# Altium schematic sheet DMMSwitchBoard.SchDoc
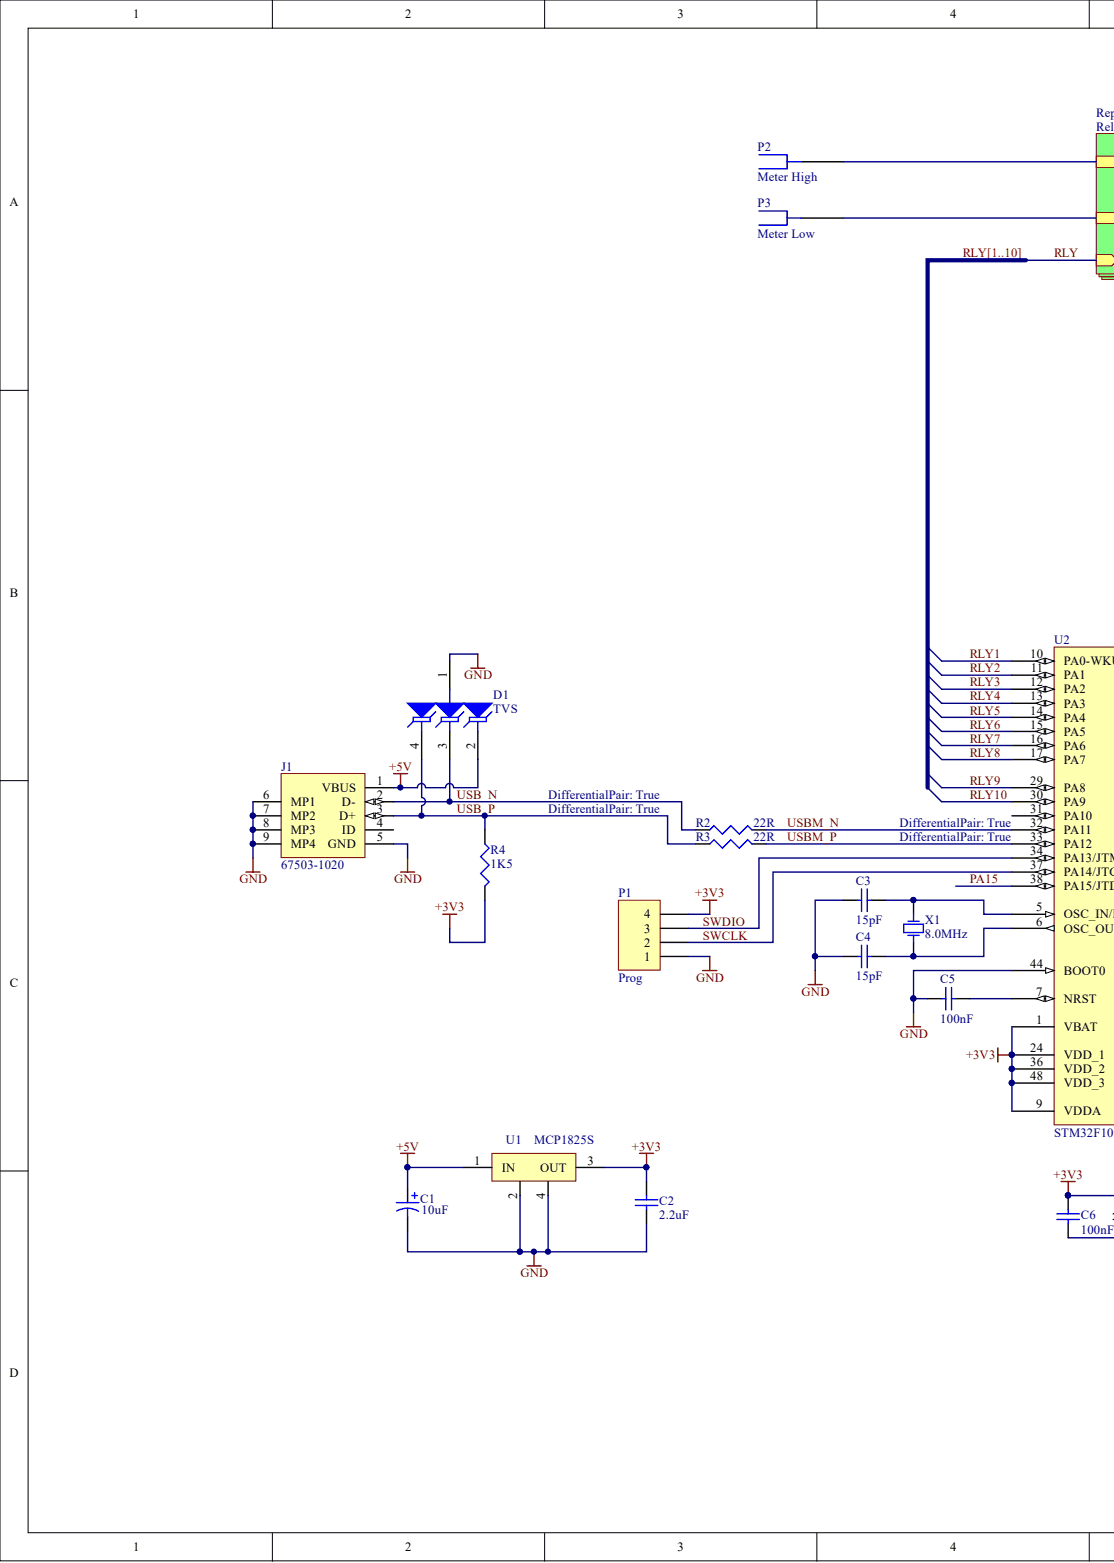
[diagram p0: DMMSwitchBoard.SchDoc, left]
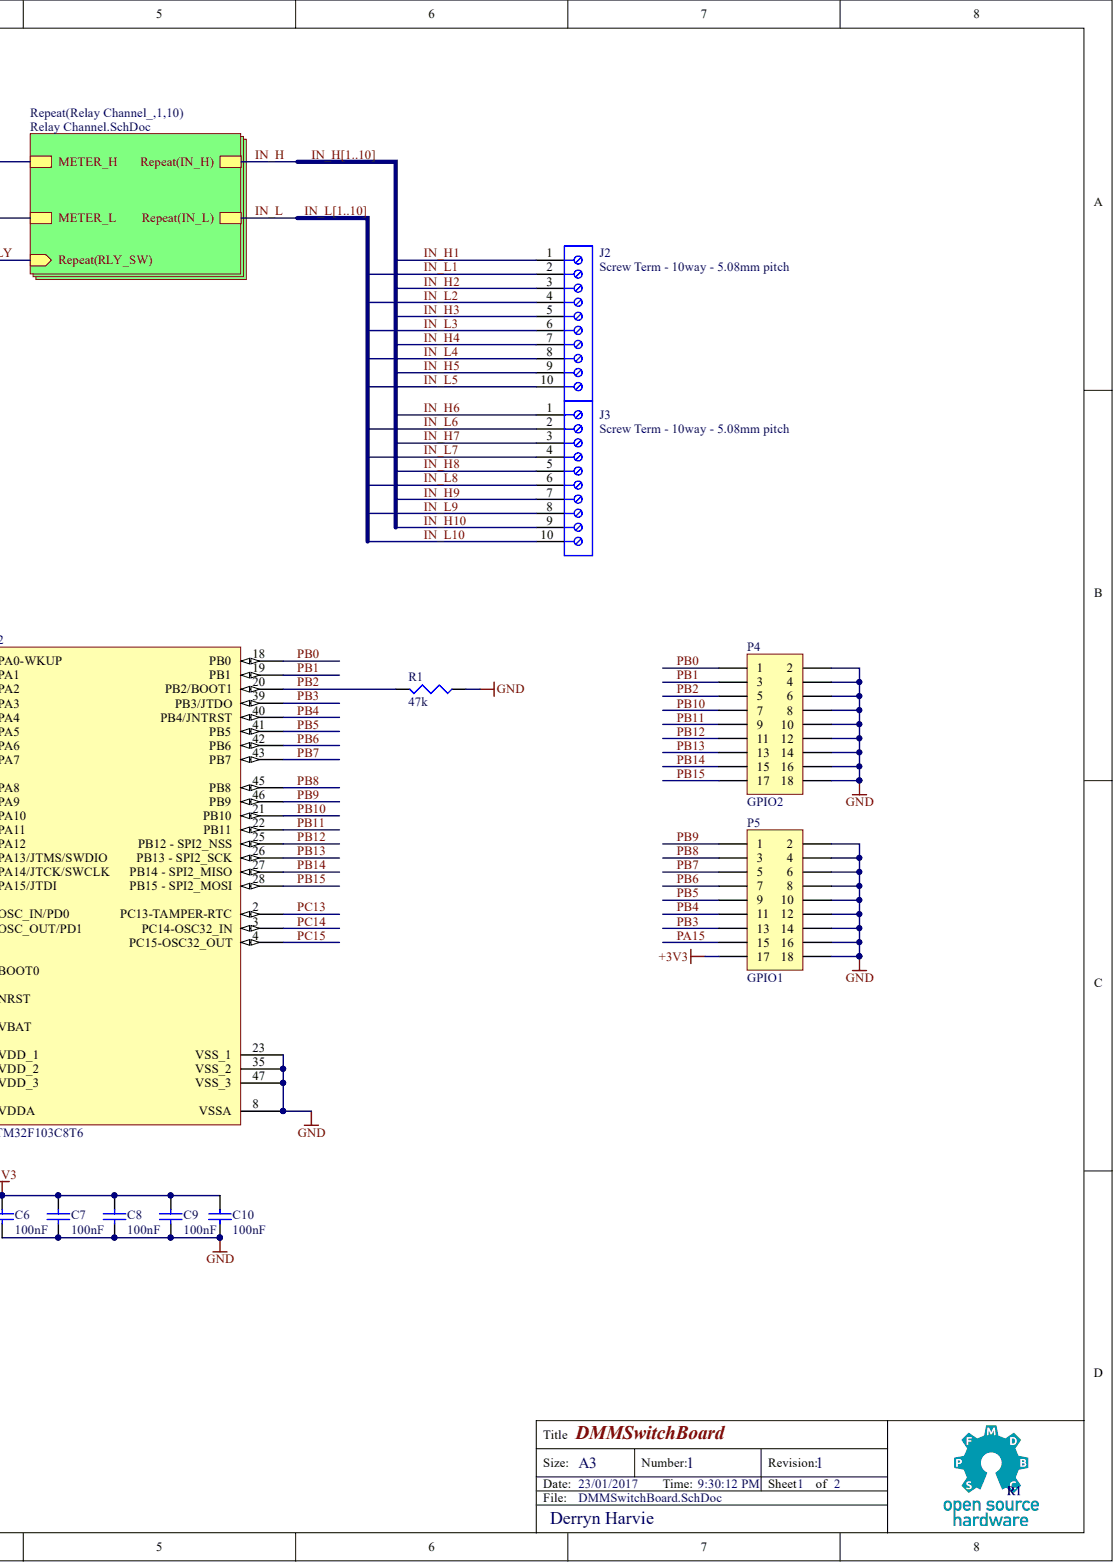
[diagram p1: DMMSwitchBoard.SchDoc, right]

## Parts
C1  value 10uF  [0805]  (hidden comment: Cap Pol2)
C2  value 2.2uF  [0603]  (hidden comment: C0603)
C3  value 15pF  [0603]  (hidden comment: C0603)
C4  value 15pF  [0603]  (hidden comment: C0603)
C5  value 100nF  [0603]  (hidden comment: C0603)
C6  value 100nF  [0603]  (hidden comment: C0603)
C7  value 100nF  [0603]  (hidden comment: C0603)
C8  value 100nF  [0603]  (hidden comment: C0603)
C9  value 100nF  [0603]  (hidden comment: C0603)
C10  value 100nF  [0603]  (hidden comment: C0603)
D1  TVS  [SOT143-4]
J1  67503-1020  [MOLX-67503-1020_V]
J2  Screw Term - 10way - 5.08mm pitch  [ST-30W-5.08P]
J3  Screw Term - 10way - 5.08mm pitch  [ST-30W-5.08P]
P1  Prog  [HDR1X4]
P2  Meter High  [Banana_4mm_RA]
P3  Meter Low  [Banana_4mm_RA]
P4  GPIO2  [HDR2X9]
P5  GPIO1  [HDR2X9]
R1  value 47k  [0603]  (hidden comment: Resistor)
R2  value 22R  [0603]  (hidden comment: Resistor)
R3  value 22R  [0603]  (hidden comment: Resistor)
R4  value 1K5  [0603]  (hidden comment: Resistor)
U1  MCP1825S  [SOT223]
U2  STM32F103C8T6  [LQFP48_L]
X1  8.0MHz  [8MHz Crystal]

## Nets
+3V3: C2.1 C6.1 C7.1 C8.1 C9.1 C10.1 P1.4 P5.17 R4.2 U1.3(OUT) U2.1(VBAT) U2.9(VDDA) U2.24(VDD_1) U2.36(VDD_2) U2.48(VDD_3)
+5V: C1.1 D1.2 J1.1(VBUS) U1.1(IN)
GND: C1.2 C2.2 C3.1 C4.1 C5.1 C6.2 C7.2 C8.2 C9.2 C10.2 D1.1 J1.5(GND) J1.6(MP1) J1.7(MP2) J1.8(MP3) J1.9(MP4) P1.1 P4.2 P4.4 P4.6 P4.8 P4.10 P4.12 P4.14 P4.16 P4.18 P5.2 P5.4 P5.6 P5.8 P5.10 P5.12 P5.14 P5.16 P5.18 R1.1 U1.2 U1.4 U2.8(VSSA) U2.23(VSS_1) U2.35(VSS_2) U2.44(BOOT0) U2.47(VSS_3)
IN_H1: J2.1  [also labelled IN_H]
IN_H2: J2.3  [also labelled IN_H]
IN_H3: J2.5  [also labelled IN_H]
IN_H4: J2.7  [also labelled IN_H]
IN_H5: J2.9  [also labelled IN_H]
IN_H6: J3.1  [also labelled IN_H]
IN_H7: J3.3  [also labelled IN_H]
IN_H8: J3.5  [also labelled IN_H]
IN_H9: J3.7  [also labelled IN_H]
IN_H10: J3.9  [also labelled IN_H]
IN_L1: J2.2  [also labelled IN_L]
IN_L2: J2.4  [also labelled IN_L]
IN_L3: J2.6  [also labelled IN_L]
IN_L4: J2.8  [also labelled IN_L]
IN_L5: J2.10  [also labelled IN_L]
IN_L6: J3.2  [also labelled IN_L]
IN_L7: J3.4  [also labelled IN_L]
IN_L8: J3.6  [also labelled IN_L]
IN_L9: J3.8  [also labelled IN_L]
IN_L10: J3.10  [also labelled IN_L]
PA15: P5.15 U2.38(PA15/JTDI)
PB0: P4.1 U2.18(PB0)
PB1: P4.3 U2.19(PB1)
PB2: P4.5 R1.2 U2.20(PB2/BOOT1)
PB3: P5.13 U2.39(PB3/JTDO)
PB4: P5.11 U2.40(PB4/JNTRST)
PB5: P5.9 U2.41(PB5)
PB6: P5.7 U2.42(PB6)
PB7: P5.5 U2.43(PB7)
PB8: P5.3 U2.45(PB8)
PB9: P5.1 U2.46(PB9)
PB10: P4.7 U2.21(PB10)
PB11: P4.9 U2.22(PB11)
PB12: P4.11 U2.25(PB12_-_SPI2_NSS)
PB13: P4.13 U2.26(PB13_-_SPI2_SCK)
PB14: P4.15 U2.27(PB14_-_SPI2_MISO)
PB15: P4.17 U2.28(PB15_-_SPI2_MOSI)
PC13: U2.2(PC13-TAMPER-RTC)
PC14: U2.3(PC14-OSC32_IN)
PC15: U2.4(PC15-OSC32_OUT)
RLY1: U2.10(PA0-WKUP)  [also labelled RLY]
RLY2: U2.11(PA1)  [also labelled RLY]
RLY3: U2.12(PA2)  [also labelled RLY]
RLY4: U2.13(PA3)  [also labelled RLY]
RLY5: U2.14(PA4)  [also labelled RLY]
RLY6: U2.15(PA5)  [also labelled RLY]
RLY7: U2.16(PA6)  [also labelled RLY]
RLY8: U2.17(PA7)  [also labelled RLY]
RLY9: U2.29(PA8)  [also labelled RLY]
RLY10: U2.30(PA9)  [also labelled RLY]
SWCLK: P1.2 U2.37(PA14/JTCK/SWCLK)
SWDIO: P1.3 U2.34(PA13/JTMS/SWDIO)
USB_N: D1.3 J1.2(D-) R2.1
USB_P: D1.4 J1.3(D+) R3.1 R4.1
USBM_N: R2.2 U2.32(PA11)
USBM_P: R3.2 U2.33(PA12)
NetC3_2: C3.2 U2.5(OSC_IN/PD0) X1.2
NetC4_2: C4.2 U2.6(OSC_OUT/PD1) X1.1
NetC5_2: C5.2 U2.7(NRST)
NetJ1_4: J1.4(ID)
NetP2_1: P2.1
NetP3_1: P3.1
NetU2_31: U2.31(PA10)

## Library data of the parts
C1 = Tantalum Capacitor: Surface Mount Tantalum Capacitor; Component Kind: Standard; Library Name: MainLib.IntLib
C2-C10 = C0603: Ceramic Chip Capacitor - Standard; Note: Footprint corresponds to dimensions for bulk cassette packaging. This case size has tightened tolerances.; Code_IPC: 1608 [0603]; Code_JEITA: 0603; Component Kind: Standard; Library Name: MainLib.IntLib; PackageReference: 0603; Published: 17-Jul-2002; Simulation: CAP; Publisher: Altium Limited
D1 = TVS Array - SP0503BAHTG: TVS Array USB; Component Kind: Standard; Library Name: MainLib.IntLib; Supplier 1: Octopart; Supplier Part Number 1: Digi-Key - F2715TR-ND
J1 = CMP-0411-00002-1: USB, MINI, SMD, RA KME04-USBMU03A01; Manufacturer: Molex; Component Kind: Standard; ComponentLink1Description: Manufacturer URL; ComponentLink1URL: http://www.molex.com/; ComponentLink2Description: Datasheet; ComponentLink2URL: http://www.molex.com/pdm_docs/sd/675031020_sd.pdf; DatasheetVersion: Rev F, 06/2009; Library Name: Altium Content Vault; Mounting Technology: Surface Mount; PackageDescription: Mini USB Connector, SMT, Righer Angle; PackageReference: 67503-1020; PartNumber: 67503-1020; Terminal Pitch: 0.8 mm
J2 J3 = Screw Term - 10way - 5.08mm pitch: Terminal Block, Pitch 5.08mm, 10 Way; Component Kind: Standard; Library Name: MainSchLib.SchLib
P1 = Header 4: Header, 4-Pin; Component Kind: Standard; LatestRevisionDate: 17-Jul-2002; LatestRevisionNote: Re-released for DXP Platform.; Library Name: Miscellaneous Connectors.IntLib; Publisher: Altium Limited
P2 P3 = Jack - Banana - Right Angle: Banana Jack 4mm Right Angle; Component Kind: Standard; Library Name: MainLib.IntLib
P4 P5 = Header 9X2: Header, 9-Pin, Dual row; Component Kind: Standard; LatestRevisionDate: 17-Jul-2002; LatestRevisionNote: Re-released for DXP Platform.; Library Name: Miscellaneous Connectors.IntLib; Publisher: Altium Limited
U1 = MCP1825S-3302E/DB: IC REG LDO 3.3V 0.5A SOT223-3; Manufacturer: Microchip Technology; Current - Output: 500mA; Number of Regulators: 1; Regulator Topology: Positive Fixed; Voltage - Dropout (Typical): 0.21V @ 500mA; Voltage - Input: Up to 6V; Category: Integrated Circuits (ICs); Component Kind: Standard; ComponentLink1Description: http://www.microchip.com/mymicrochip/filehandler.aspx?ddocname=en531698; ComponentLink1URL: http://www.microchip.com/mymicrochip/filehandler.aspx?ddocname=en531698; Current - Limit (Min): -; Manufacturer Part Number: MCP1825S-3302E/DB; Mounting Type: Surface Mount; Operating Temperature: -40°C ~ 125°C; Package / Case: TO-261-4, TO-261AA; Packaging: Tube; Pricing 1: 1=0.71, 25=0.5948, 100=0.5394 (AUD); RoHS: RoHS Compliant; Stock 1: 6354; Supplier 1: Digi-Key; Supplier Device Package: SOT-223-3; Supplier Part Number 1: MCP1825S-3302E/DB-ND; Voltage - Output: 3.3V; Library Name: DataRecorderSchLib.SchLib
U2 = STM32F103C8T6: STM32 ARM-based 32-bit MCU with 64 Kbytes Flash, 48-pin LQFP, Industrial Temperature; ADC Resolution: 12 bit; Brand: STMicroelectronics; Core: ARM Cortex M3; Data Bus Width: 32 bit; Data RAM Size: 20 kB; Data RAM Type: SRAM; Interface Type: CAN, I2C, SPI, USART, USB; Maximum Clock Frequency: 72 MHz; Number of ADC Channels: 10; Number of I/Os: 48 I/O; Number of Timers/Counters: 3 Timer; Operating Supply Voltage: 2 V to 3.6 V; Processor Series: ARM Cortex-M; Program Memory Size: 64 kB; Supply Voltage - Max: 3.6 V; Supply Voltage - Min: 2 V; Category: ARM Microcontrollers - MCU; Component Kind: Standard; ComponentLink3Description: http://www.mouser.com/ds/2/389/CD00161566-315155.pdf; ComponentLink3URL: http://www.mouser.com/ds/2/389/CD00161566-315155.pdf; Manufacturer: STMicroelectronics; Manufacturer Part Number: STM32F103C8T6; Maximum Operating Temperature: + 85 C; Minimum Operating Temperature: - 40 C; Minimum Order Quantity: 1; Mounting Style: SMD/SMT; Package / Case: LQFP-48; Packaging: Tray; Pricing 1: 1=6.04, 10=5.14, 25=5.05, 100=4.45, 250=4.22, 500=3.76, 1000=3.18, 2500=2.94 (USD); Product: MCU; Program Memory Type: Flash; Reeling: False; RoHS: RoHS Compliant; RoHS - Mouser: Y; Series: STM32F1; Standard Pack Qty: 1500; Stock 1: 1663; Supplier 1: Mouser; Supplier Part Number 1: 511-STM32F103C8T6; Tradename: STM32; Library Name: DataRecorderSchLib.SchLib
X1 = ABL-8.000MHZ-B2.: ABRACON - ABL-8.000MHZ-B2. - CRYSTAL, 8MHZ, 18PF, HC-49US; Component Kind: Standard; ComponentLink1Description: http://www.farnell.com/datasheets/1359171.pdf; ComponentLink1URL: http://www.farnell.com/datasheets/1359171.pdf; Library Name: SdLoggerLibs.SchLib; Manufacturer: ABRACON; Manufacturer Part Number: ABL-8.000MHZ-B2.; Pricing 1: 1=0.632, 10=0.562, 50=0.46, 100=0.41, 500=0.37, 1000=0.345 (AUD); RoHS: YES; Stock 1: 40; Supplier 1: Farnell; Supplier Part Number 1: 7940397
R1-R4 = Resistor: Component Kind: Standard; Description: Resistor; Library Name: DataRecorderSchLib.SchLib
